# Altium schematic sheet I2C.SchDoc
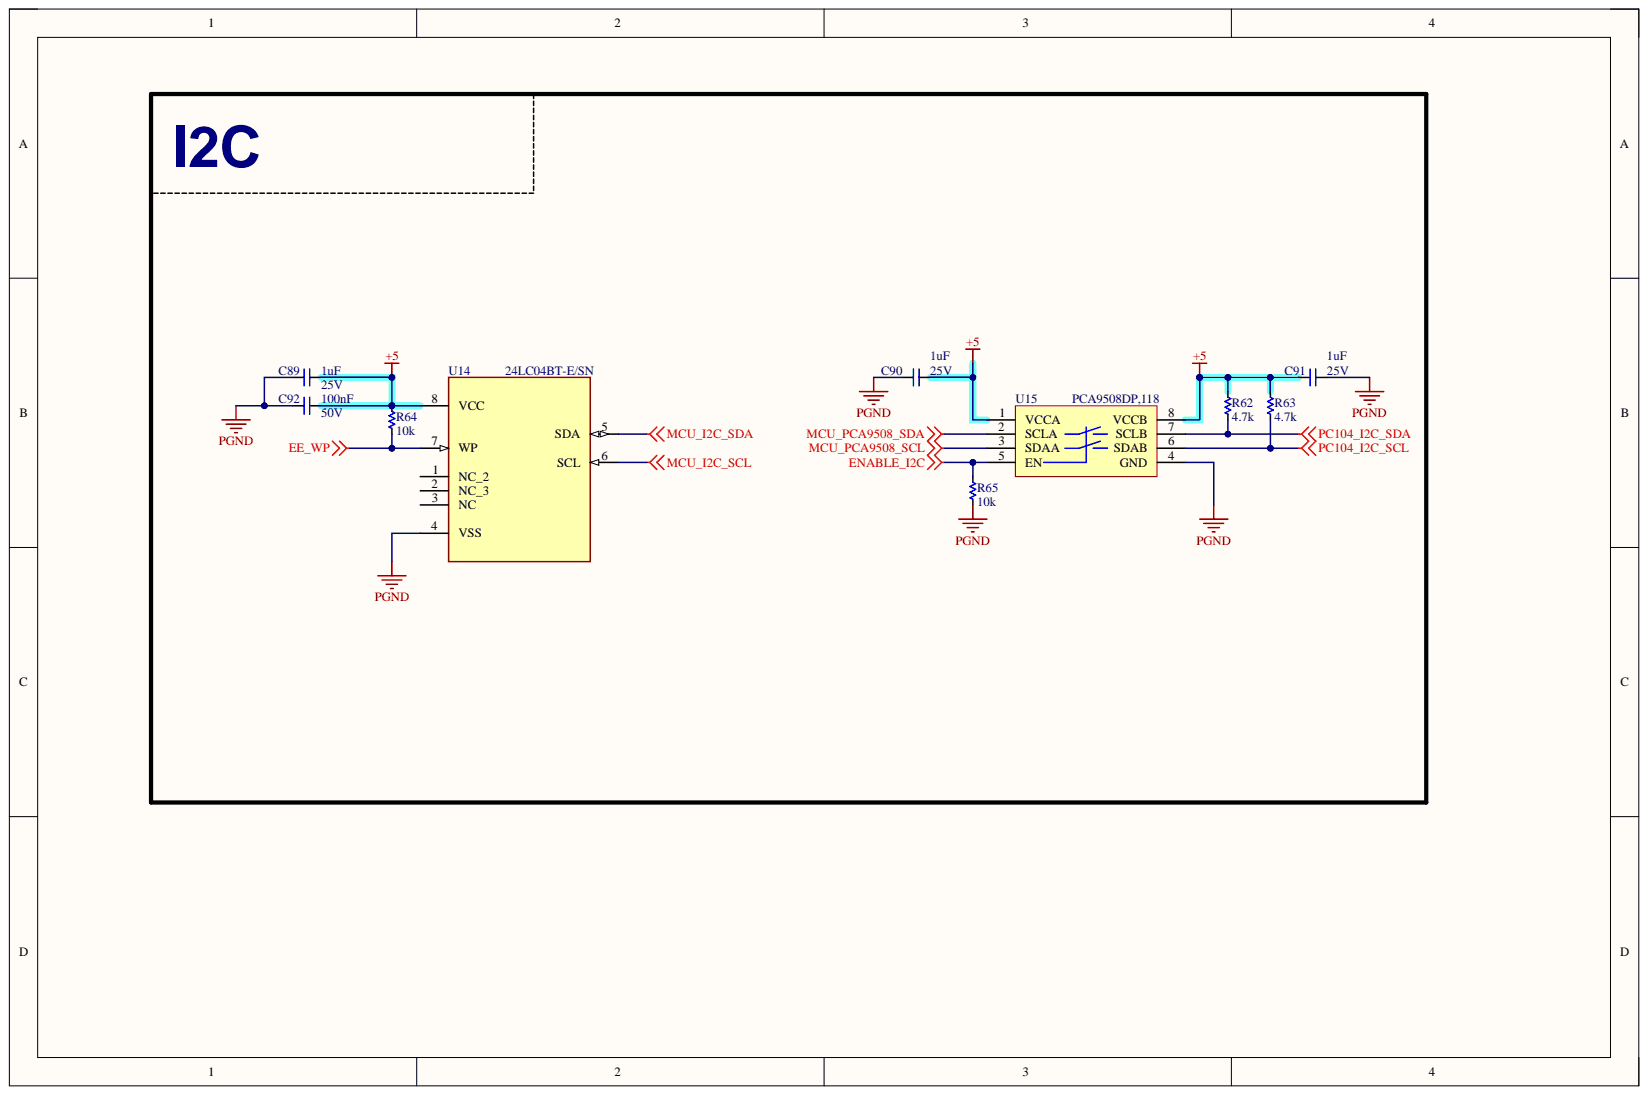
[diagram p0: I2C.SchDoc]

## Parts
C89  1uF  Voltage: 25V  [CAP_C_1608X80]
C90  1uF  Voltage: 25V  [CAP_C_1608X80]
C91  1uF  Voltage: 25V  [CAP_C_1608X80]
C92  100nF  Voltage: 50V  [CAP_C_1608X80]
R62  4.7k  [RES_C_1608X60]
R63  4.7k  [RES_C_1608X60]
R64  10k  [RES_C_1608X60]
R65  10k  [RES_C_1608X60]
U14  24LC04BT-E/SN  [SOIC_127]
U15  PCA9508DP,118  [TSOP8]

## Nets
+5: C89.1 C90.2 C91.2 C92.1 R62.1 R63.1 R64.1 U14.8(VCC) U15.1(VCCA) U15.8(VCCB)
EE_WP: R64.2 U14.7(WP)
ENABLE_I2C: R65.2 U15.5(EN)
MCU_I2C_SCL: U14.6(SCL)
MCU_I2C_SDA: U14.5(SDA)
MCU_PCA9508_SCL: U15.3(SDAA)
MCU_PCA9508_SDA: U15.2(SCLA)
PC104_I2C_SCL: R63.2 U15.6(SDAB)
PC104_I2C_SDA: R62.2 U15.7(SCLB)
PGND: C89.2 C90.1 C91.1 C92.2 R65.1 U14.4(VSS) U15.4(GND)

Not connected: U14 1(NC_2) 2(NC_3) 3(NC)

## Library data of the parts
C89-C92 = _CAP_C_2: CAP; Component Kind: Standard; Library Name: LABLIB.SchLib
R62-R65 = _RES_B_2_2: RES; Component Kind: Standard; Library Name: LABLIB.SchLib
U14 = 24LC04BT-E/SN: Component Kind: Standard; Library Name: KIENASIY123_LIB.IntLib
U15 = PCA9508DP,118: Component Kind: Standard; Library Name: EXP_HW_V3.SchLib
